# Altium schematic sheet [3]Ethernet.SchDoc
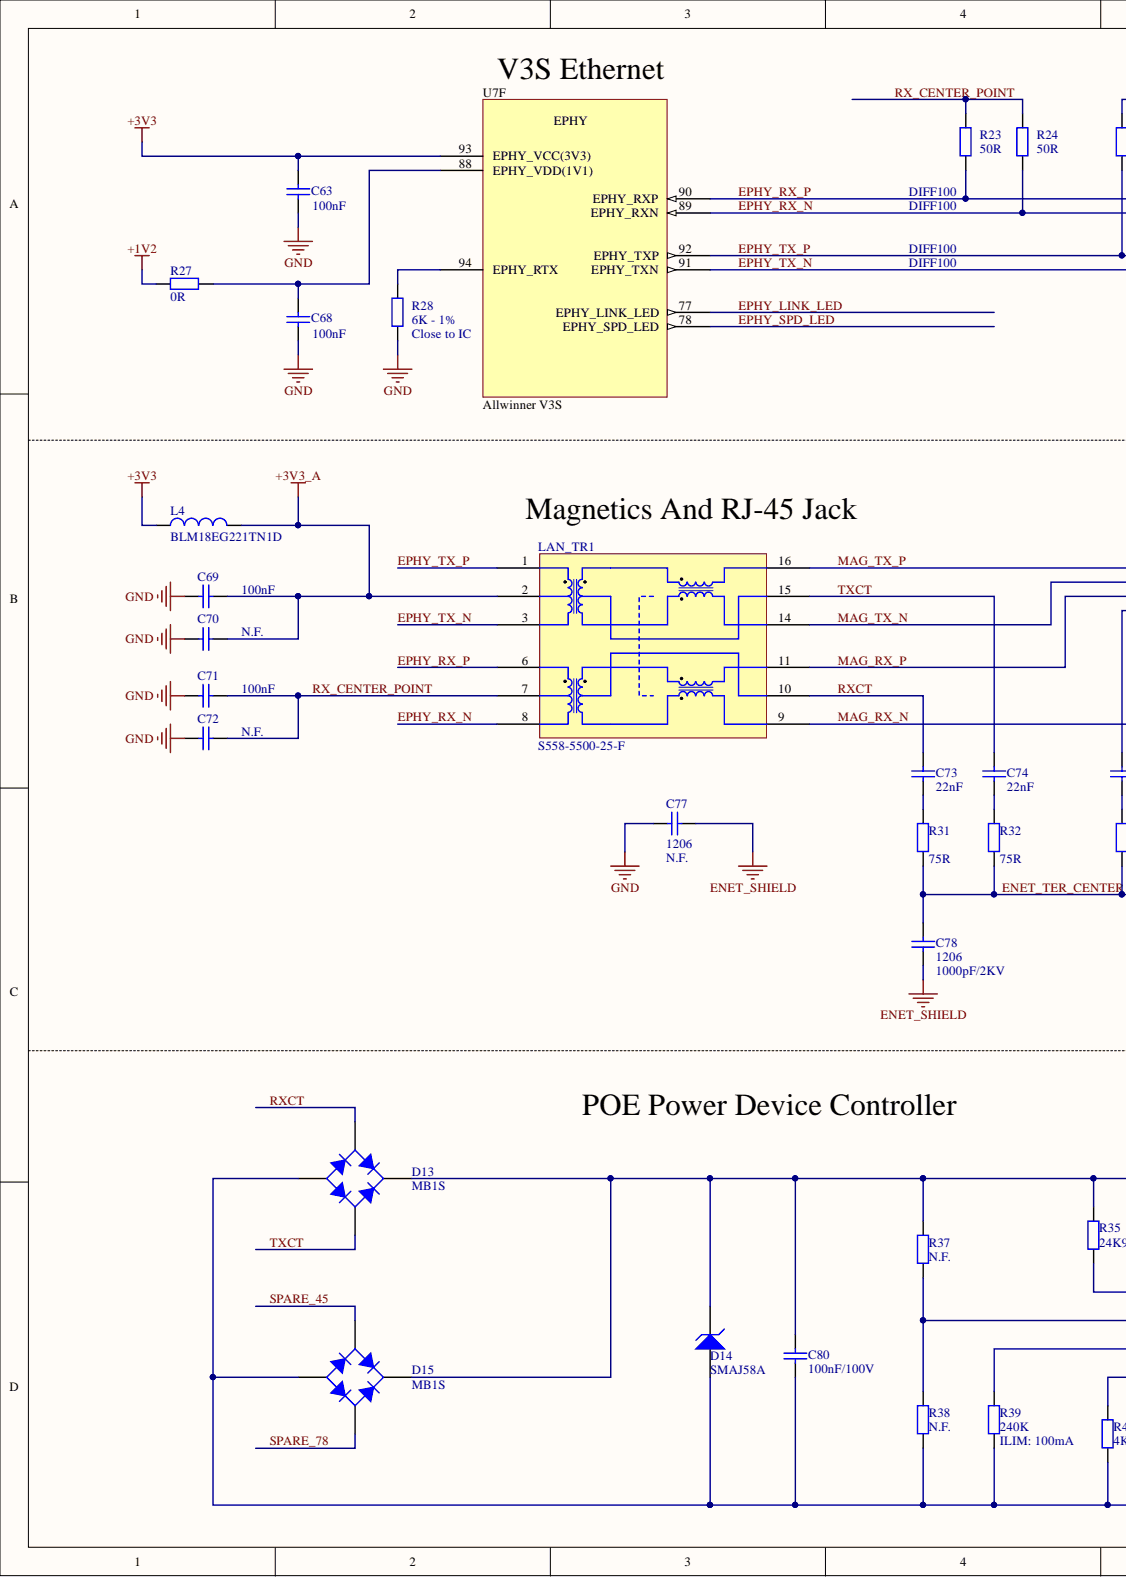
[diagram p0: [3]Ethernet.SchDoc, left]
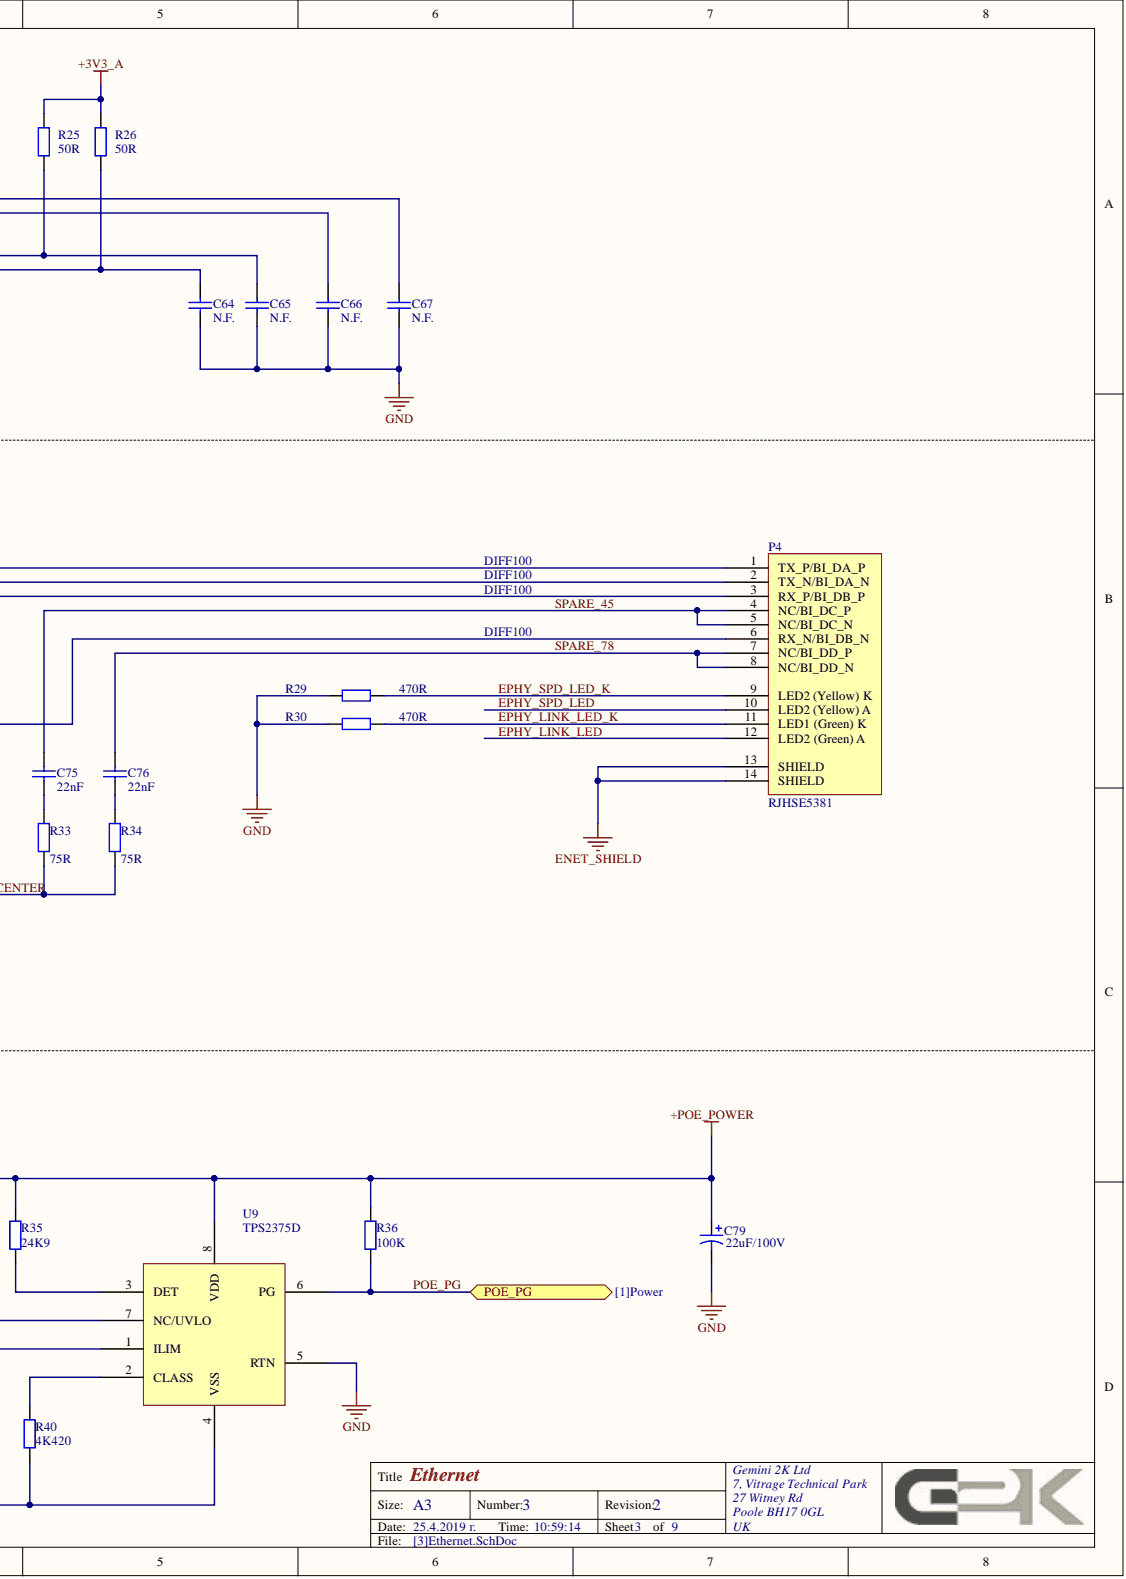
[diagram p1: [3]Ethernet.SchDoc, right]

## Parts
C63  value 100nF  [1608[0603]]  (hidden comment: 0603)
C64  value N.F.  [1608[0603]]  (hidden comment: 0603)
C65  value N.F.  [1608[0603]]  (hidden comment: 0603)
C66  value N.F.  [1608[0603]]  (hidden comment: 0603)
C67  value N.F.  [1608[0603]]  (hidden comment: 0603)
C68  value 100nF  [1608[0603]]  (hidden comment: 0603)
C69  value 100nF  [1608[0603]]  (hidden comment: 0603)
C70  value N.F.  [1608[0603]]  (hidden comment: 0603)
C71  value 100nF  [1608[0603]]  (hidden comment: 0603)
C72  value N.F.  [1608[0603]]  (hidden comment: 0603)
C73  value 22nF  [1608[0603]]  (hidden comment: 0603)
C74  value 22nF  [1608[0603]]  (hidden comment: 0603)
C75  value 22nF  [1608[0603]]  (hidden comment: 0603)
C76  value 22nF  [1608[0603]]  (hidden comment: 0603)
C77  1206  value N.F.  [C1206]
C78  1206  value 1000pF/2KV  [C1206]
C79  22uF/100V  [Cap Size G]
C80  value 100nF/100V  [1608[0603]]  (hidden comment: 0603)
D13  MB1S  [MBxS Bridge]
D14  SMAJ58A  [SMA]
D15  MB1S  [MBxS Bridge]
L4  BLM18EG221TN1D  [1608[0603]]
LAN_TR1  S558-5500-25-F  [S558-5500-25-F]
P4  RJHSE5381  [RJHSE-5381]
R23  value 50R  [J1-0603]  (hidden comment: 0603)
R24  value 50R  [J1-0603]  (hidden comment: 0603)
R25  value 50R  [J1-0603]  (hidden comment: 0603)
R26  value 50R  [J1-0603]  (hidden comment: 0603)
R27  value 0R  [J1-0603]  (hidden comment: 0603)
R28  value 6K - 1%  [J1-0603]  (hidden comment: 0603)
R29  value 470R  [J1-0603]  (hidden comment: 0603)
R30  value 470R  [J1-0603]  (hidden comment: 0603)
R31  value 75R  [J1-0603]  (hidden comment: 0603)
R32  value 75R  [J1-0603]  (hidden comment: 0603)
R33  value 75R  [J1-0603]  (hidden comment: 0603)
R34  value 75R  [J1-0603]  (hidden comment: 0603)
R35  value 24K9  [J1-0603]  (hidden comment: 0603)
R36  value 100K  [J1-0603]  (hidden comment: 0603)
R37  value N.F.  [J1-0603]  (hidden comment: 0603)
R38  value N.F.  [J1-0603]  (hidden comment: 0603)
R39  value 240K  [J1-0603]  (hidden comment: 0603)
R40  value 4K420  [J1-0603]  (hidden comment: 0603)
U7  Allwinner V3S  Manufacturer Name: Allwinner  Manufacturer Part No.: V3S  [LQFP128 E-PAD - Low Density]  (part F)
U9  TPS2375D  [SOIC127P600-8AL]

## Ports
POE_PG

## Nets
+1V2: R27.2
+3V3: C63.2 L4.1 U7.93(EPHY_VCC(3V3))
+3V3_A: C69.2 C70.2 L4.2 LAN_TR1.2 R25.2 R26.2
+POE_POWER: C79.1 C80.2 D13.1 D14.2 D15.1 R35.2 R36.2 R37.2 U9.8(VDD)
ENET_SHIELD: C77.2 C78.2 P4.13(SHIELD) P4.14(SHIELD)
GND: C63.1 C64.1 C65.1 C66.1 C67.1 C68.1 C69.1 C70.1 C71.2 C72.1 C77.1 C79.2 R28.1 R29.1 R30.1 U9.5(RTN)
ENET_TER_CENTER: C78.1 R31.1 R32.1 R33.1 R34.1
EPHY_LINK_LED: P4.12(LED2_(Green)_A) U7.77(EPHY_LINK_LED)
EPHY_LINK_LED_K: P4.11(LED1_(Green)_K) R30.2
EPHY_RX_N: C66.2 LAN_TR1.8 R24.1 U7.89(EPHY_RXN)
EPHY_RX_P: C67.2 LAN_TR1.6 R23.1 U7.90(EPHY_RXP)
EPHY_SPD_LED: P4.10(LED2_(Yellow)_A) U7.78(EPHY_SPD_LED)
EPHY_SPD_LED_K: P4.9(LED2_(Yellow)_K) R29.2
EPHY_TX_N: C64.2 LAN_TR1.3 R26.1 U7.91(EPHY_TXN)
EPHY_TX_P: C65.2 LAN_TR1.1 R25.1 U7.92(EPHY_TXP)
MAG_RX_N: LAN_TR1.9 P4.6(RX_N/BI_DB_N)
MAG_RX_P: LAN_TR1.11 P4.3(RX_P/BI_DB_P)
MAG_TX_N: LAN_TR1.14 P4.2(TX_N/BI_DA_N)
MAG_TX_P: LAN_TR1.16 P4.1(TX_P/BI_DA_P)
POE_PG: R36.1 U9.6(PG) ; port POE_PG
RX_CENTER_POINT: C71.1 C72.2 LAN_TR1.7 R23.2 R24.2
RXCT: C73.2 D13.4 LAN_TR1.10
SPARE_45: C75.2 D15.4 P4.4(NC/BI_DC_P) P4.5(NC/BI_DC_N)
SPARE_78: C76.2 D15.2 P4.7(NC/BI_DD_P) P4.8(NC/BI_DD_N)
TXCT: C74.2 D13.2 LAN_TR1.15
NetC68_2: C68.2 R27.1 U7.88(EPHY_VDD(1V1))
NetC73_1: C73.1 R31.2
NetC74_1: C74.1 R32.2
NetC75_1: C75.1 R33.2
NetC76_1: C76.1 R34.2
NetC80_1: C80.1 D13.3 D14.1 D15.3 R38.1 R39.1 R40.1 U9.4(VSS)
NetR28_2: R28.2 U7.94(EPHY_RTX)
NetR35_1: R35.1 U9.3(DET)
NetR37_1: R37.1 R38.2 U9.7(NC/UVLO)
NetR39_2: R39.2 U9.1(ILIM)
NetR40_2: R40.2 U9.2(CLASS)

## Library data of the parts
C63 C68 C69 C71 C80 = Cap: Capacitor; Note: We have them in Bulgaria; Case Code (in): 0603; Component Kind: Standard; LatestRevisionDate: 17-Jul-2002; LatestRevisionNote: Re-released for DXP Platform.; Library Name: Miscellaneous Devices.IntLib; PackageDescription: Radial Cap, Thru-Hole; 2 Leads; 0.3 in Pin Spacing; PackageReference: RAD-0.3; Published: 8-Jun-2000; Signal Integrity: Cap; Simulation: CAP; Publisher: Altium Limited
  C63 C68 C69 C71: Distributor Link: http://uk.farnell.com/kemet/c0603x104k4ractu/cap-mlcc-x7r-100nf-16v-0603/dp/1414027
  C80: Distributor Link: https://uk.farnell.com/avx/06031c104kat2a/cap-0-1-f-100v-10-x7r-0603/dp/2749806RL
C64-C67 C70 C72-C78 = Cap: Capacitor; Component Kind: Standard; LatestRevisionDate: 17-Jul-2002; LatestRevisionNote: Re-released for DXP Platform.; Library Name: Miscellaneous Devices.IntLib; PackageDescription: Radial Cap, Thru-Hole; 2 Leads; 0.3 in Pin Spacing; PackageReference: RAD-0.3; Published: 8-Jun-2000; Signal Integrity: Cap; Simulation: CAP; Publisher: Altium Limited
  C64-C67 C70 C72: Case Code (in): 0603
  C73-C76: Case Code (in): 0603; Distributor Link: https://uk.farnell.com/kemet/c0603c223k1ractu/cap-0-022-f-100v-10-x7r-0603/dp/1865548
  C77: Case Code (in): 1206
  C78: Case Code (in): 1206; Distributor Link: https://www.mouser.bg/ProductDetail/Yageo/CC1206KKX7RDBB102?qs=sGAEpiMZZMs0AnBnWHyRQDvo%2fxRt9F0%2fdXxIKCNcZXs%3d
C79 = Cap Pol3: Polarized Capacitor (Surface Mount); Manufacturer Name: Nichicon; Manufacturer Part No.: UUR2A220MNL1GS; G2K_PN: G-CAP-C22UF-UUR2A220MNL1GS-01; Component Kind: Standard; Distributor Link: https://eu.mouser.com/ProductDetail/Nichicon/UUR2A220MNL1GS?qs=7V%2FSUxyM1KfdJTu31QkurQ%3D%3D; Library Name: Miscellaneous Devices.IntLib; Value: 22uF/100V
D13 D15 = Bridge1: Full Wave Diode Bridge; Manufacturer Name: ON Semiconductor / Fairchild; Note: Get about 5 more of this; Component Kind: Standard; DatasheetVersion: E2732B; Distributor Link: https://eu.mouser.com/ProductDetail/ON-Semiconductor-Fairchild/MB1S?qs=DPwKKv7J1fwyOHno5d2nnw%3D%3D; LatestRevisionDate: 29-May-2009; LatestRevisionNote: Sch pins A & K swapped.; Library Name: Miscellaneous Devices.IntLib; Manufacturer Part No.: MB1S; PackageDescription: Bridge Rectifier; 4 Terminals; Body 9.4 x 9.4 mm (typ); Pitch 5.08 mm; PackageReference: D-38; Published: 8-Jun-2000; Simulation: BRIDGE; Publisher: Altium Limited
D14 = D Zener: Zener Diode; Manufacturer Part No.: Littelfuse; G2K_PN: G-ZEN-SMAJ58A-13-F-SMA-01; Note: Get about 5 more of this; Component Kind: Standard; Distributor Link: https://eu.mouser.com/ProductDetail/Littelfuse/SMAJ58A?qs=2VFNtWizgiepPOMiS0Encw%3D%3D; LatestRevisionDate: 17-Jul-2002; LatestRevisionNote: Re-released for DXP Platform.; Library Name: Miscellaneous Devices.IntLib; Manufacturer Name: SMAJ58A; PackageReference: DIODE-0.7; Published: 8-Jun-2000; Signal Integrity: D Zener; Simulation: ZENER; Publisher: Altium Limited
L4 = Inductor: Manufacturer Name: Murata; Component Kind: Standard; Description: Inductor; Distributor Link: http://uk.farnell.com/murata/blm18eg221tn1d/ferrite-bead-0-15ohm-1a-0603/dp/1515717; Library Name: Miscellaneous Devices.IntLib; Manufacturer Part No.: BLM18EG221TN1D; Signal Integrity: Inductor; Simulation: INDUCTOR
LAN_TR1 = LAN_TR: Manufacturer Name: Bel Magnetic Solutions; Component Kind: Standard; Distributor Link: https://eu.mouser.com/ProductDetail/Bel-Magnetic-Solutions/S558-5500-25-F?qs=SRYZG9HaIQ2wKH5stXp9Qg%3D%3D; Library Name: RJ45.SchLib; Manufacturer Part No.: S558-5500-25-F
P4 = RJHSE3381: Manufacturer Name: Amphenol ICC; Component Kind: Standard; Distributor Link: https://uk.farnell.com/amphenol-icc-commercial-products/rjhse-5381/connector-modular-jack/dp/1462758; Library Name: RJ45.SchLib; Manufacturer Part No.: RJHSE5381
R23-R26 R29-R38 R40 = Res2: Resistor; Case Code (in): 0603; Component Kind: Standard; LatestRevisionDate: 17-Jul-2002; LatestRevisionNote: Re-released for DXP Platform.; Library Name: Miscellaneous Devices.IntLib; PackageDescription: Axial Device, Thru-Hole; 2 Leads; 0.4 in Pin Spacing; PackageReference: AXIAL-0.4; Published: 8-Jun-2000; Signal Integrity: Res; Simulation: RESISTOR; Publisher: Altium Limited
  R23-R26: Distributor Link: https://eu.mouser.com/ProductDetail/Yageo/RT0603BRE0750RL?qs=sGAEpiMZZMvdGkrng054twOk7UJM2yUKqyvtAcMxgXcQVzEGBStvPg%3d%3d
  R29 R30: Distributor Link: https://uk.farnell.com/multicomp/mcwr06x4700ftl/res-470r-1-0-1w-0603-thick-film/dp/2447374
  R31-R34: Distributor Link: https://eu.mouser.com/ProductDetail/Yageo/RC0603FR-0775RL?qs=sGAEpiMZZMvdGkrng054txdMlaQGj9fiU1NgwFrvtYA%3d
  R35: Distributor Link: https://uk.farnell.com/multicomp/mcwr06x2492ftl/res-24k9-1-0-1w-thick-film/dp/2447309
  R36: Distributor Link: https://uk.farnell.com/vishay/crcw060310k0fkeahp/res-10k-1-0-25w-0603-thick-film/dp/1738918?st=CRCW060310K0FKEA
  R40: Distributor Link: https://uk.farnell.com/vishay/crcw06034k22fkea/res-4k22-1-0-1w-0603-thick-film/dp/2138395
R27 = Res2: Resistor; Note: We have them in Bulgaria; Case Code (in): 0603; Component Kind: Standard; Distributor Link: http://uk.farnell.com/yageo/rc0603fr-070rl/res-thick-film-0r-1-0-1w-0603/dp/2309106; LatestRevisionDate: 17-Jul-2002; LatestRevisionNote: Re-released for DXP Platform.; Library Name: Miscellaneous Devices.IntLib; PackageDescription: Axial Device, Thru-Hole; 2 Leads; 0.4 in Pin Spacing; PackageReference: AXIAL-0.4; Published: 8-Jun-2000; Signal Integrity: Res; Simulation: RESISTOR; Publisher: Altium Limited
R28 = Res2: Resistor; Layout Note: Close to IC; Value: 6K - 1%; Case Code (in): 0603; Component Kind: Standard; Distributor Link: https://uk.farnell.com/panasonic-electronic-components/erj3ekf6041v/res-6k04-1-0-1w-0603-thick-film/dp/2059386; LatestRevisionDate: 17-Jul-2002; LatestRevisionNote: Re-released for DXP Platform.; Library Name: Miscellaneous Devices.IntLib; PackageDescription: Axial Device, Thru-Hole; 2 Leads; 0.4 in Pin Spacing; PackageReference: AXIAL-0.4; Published: 8-Jun-2000; Signal Integrity: Res; Simulation: RESISTOR; Publisher: Altium Limited
R39 = Res2: Resistor; ILIM: 100mA; Case Code (in): 0603; Component Kind: Standard; Distributor Link: https://uk.farnell.com/vishay/crcw0603240kfkea/res-240k-1-0-1w-0603-thick-film/dp/1469786; LatestRevisionDate: 17-Jul-2002; LatestRevisionNote: Re-released for DXP Platform.; Library Name: Miscellaneous Devices.IntLib; PackageDescription: Axial Device, Thru-Hole; 2 Leads; 0.4 in Pin Spacing; PackageReference: AXIAL-0.4; Published: 8-Jun-2000; Signal Integrity: Res; Simulation: RESISTOR; Publisher: Altium Limited
U9 = TPS237x: IEEE 802.3af PoE POWERED DEVICE CONTROLLERS; Manufacturer Name: Texas Instruments; Manufacturer Part No.: TPS2377D; Note: Get about 5 more of this; Component Kind: Standard; Distributor Link: https://eu.mouser.com/ProductDetail/Texas-Instruments/TPS2377D?qs=zwk82MlA57ZTVj2ZkMcRbg%3D%3D; Library Name: PapayaPi.SchLib
U7 = Allwinner V3S: Component Kind: Standard; Library Name: Allwinner.SchLib
